# Altium schematic sheet STM32_Peripherals.SchDoc
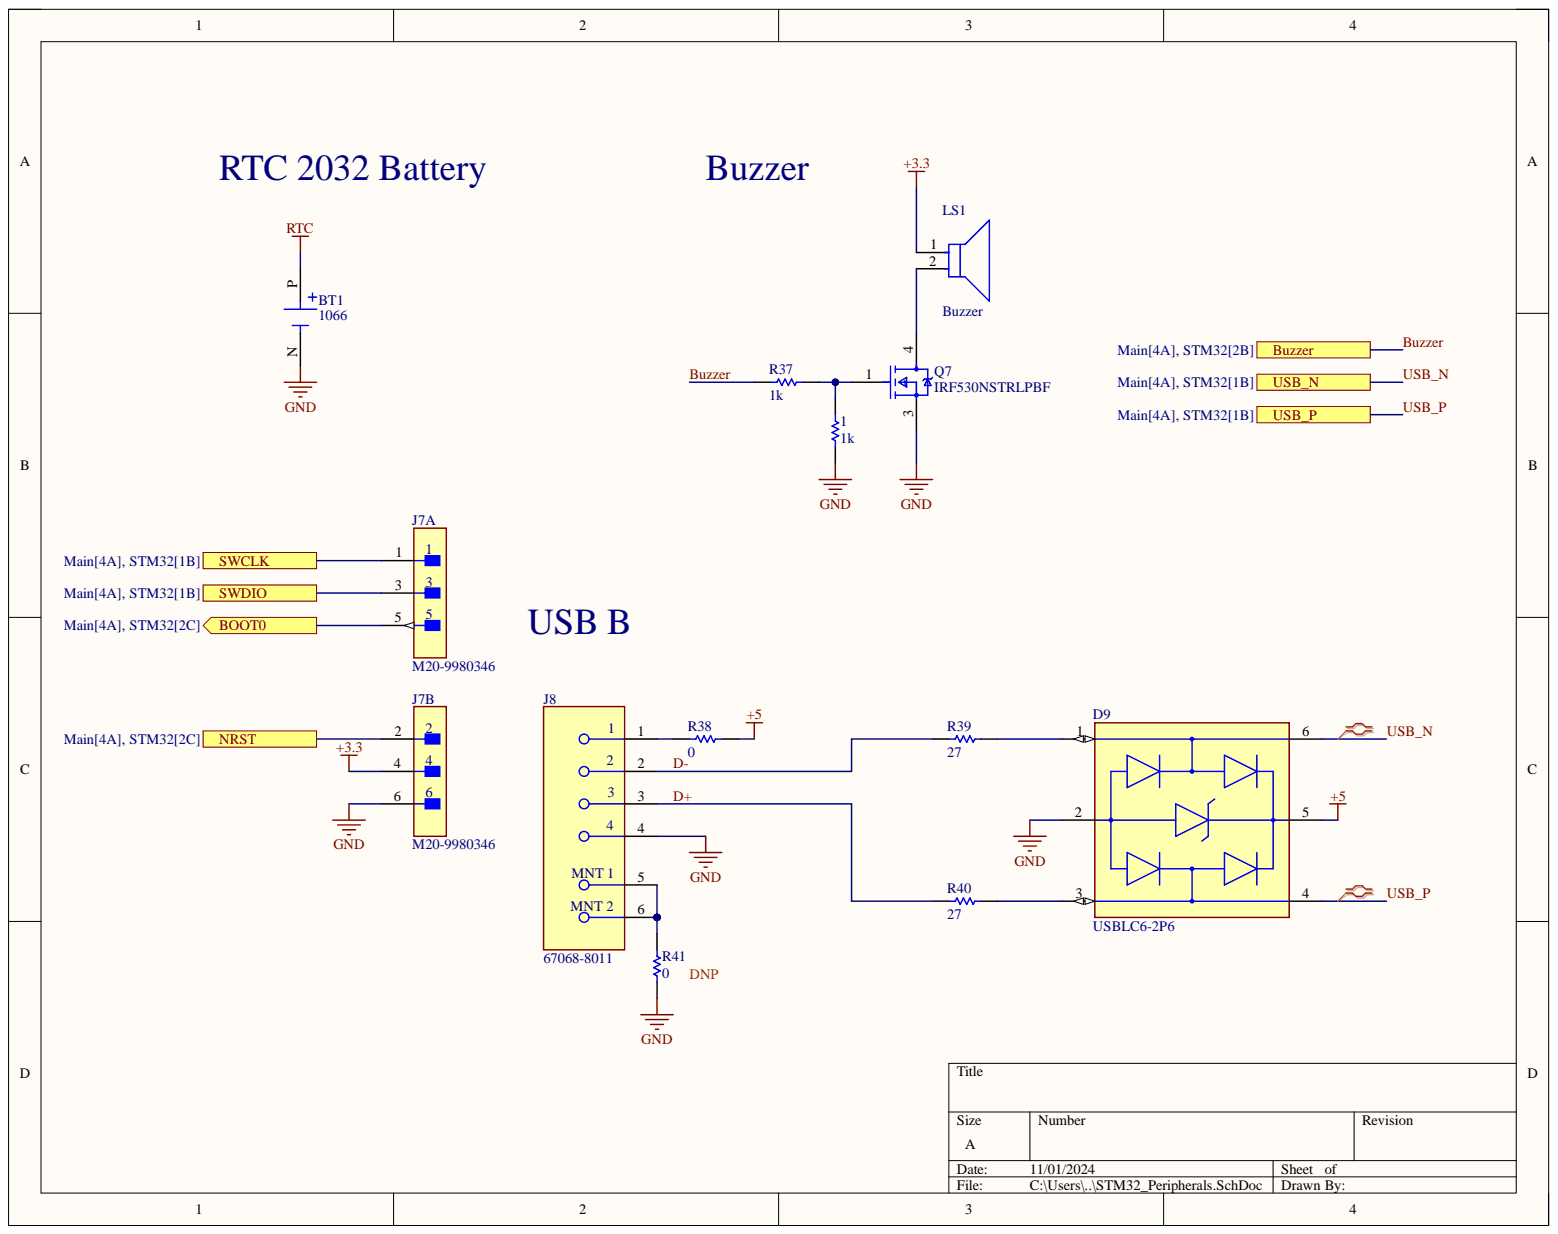
[diagram p0: STM32_Peripherals.SchDoc]

## Parts
1  1k  [RESC2013X50X30LL20T20]
BT1  1066  [BAT_1066]
D9  USBLC6-2P6  [FP-SOT-666-MFG]
J7  M20-9980346  [FP-M20-9980346-MFG]  (parts A,B)
J8  67068-8011  [FP-67068-8011-MFG]
LS1  Buzzer  [FP-PKB24SPCH3601-B0-MFG]
Q7  IRF530NSTRLPBF  [FP-PG-TO263-3-MFG]
R37  1k  [RESC2013X50X30LL20T20]
R38  0  [RESC2013X50X30LL20T20]
R39  27  [RESC2013X50X30LL20T20]
R40  27  [RESC2013X50X30LL20T20]
R41  0  [RESC2013X50X30LL20T20]

## Ports
BOOT0, Buzzer, NRST, SWCLK, SWDIO, USB_N, USB_P

## Nets
+3.3: J7.4 LS1.1
+5: D9.5 R38.2
GND: 1.1 BT1.N D9.2 J7.6 J8.4 Q7.3 R41.1
RTC: BT1.P
BOOT0: J7.5 ; port BOOT0
Buzzer: R37.1 ; port Buzzer
D+: J8.3 R40.1
D-: J8.2 R39.1
NRST: J7.2 ; port NRST
SWCLK: J7.1 ; port SWCLK
SWDIO: J7.3 ; port SWDIO
USB_N: D9.6 ; port USB_N
USB_P: D9.4 ; port USB_P
Net1_2: 1.2 Q7.1 R37.2
NetD9_1: D9.1 R39.2
NetD9_3: D9.3 R40.2
NetJ8_1: J8.1 R38.1
NetJ8_5: J8.5 J8.6 R41.2
NetLS1_2: LS1.2 Q7.4

## Library data of the parts
1 R37-R41 = CMP-2001-04896-1: Manufacturer: Vishay Dale; Part Number: CRCW08050000Z0EA; Component Kind: Standard; Library Name: Altium Content Vault
D9 = CMP-12225-000058-1: TVS DIODE 5.25V 17V SOT666; Breakdown Voltage: 6V; Capacitance: 3.5pF; Direction: Unidirectional; Element Configuration: Dual; ESD Protection: Yes; Leakage Current: 150nA; Number of Bidirectional Channels: 2; Number of Elements: 1; Operating Supply Voltage: 5V; Peak Pulse Current: 5A; Test Current: 1mA; Case/Package: SOT-666; Clamping Voltage: 17V; Component Kind: Standard; Contact Plating: Tin; Depth: 1.3mm; Height: 0.6mm; Lead Free: Lead Free; Length: 1.7mm; Library Name: Altium Content Vault; Max Breakdown Voltage: 6V; Max Operating Temperature: 125°C; Max Reverse Leakage Current: 150nA; Min Breakdown Voltage: 6V; Min Operating Temperature: -40°C; Mount: Surface Mount; Number of Channels: 2; Number of Pins: 6; Package Quantity: 1; Packaging: Tape and Reel; Polarity: Unidirectional; Power Line Protection: Yes; Radiation Hardening: No; REACH SVHC: No SVHC; Reverse Breakdown Voltage: 6V; Reverse Standoff Voltage: 5V; Termination: SMD/SMT; Width: 1.3mm; Working Voltage: 5V
J7 = CMP-2000-06636-2: CONN HEADER VERT 6POS 2.54MM; Manufacturer: Harwin; Conn Gender: Male; Conn Orientation: Vertical; Current Rating: 3A; Number Of Contacts: 6; Automotive: No; Category: Conn; Component Kind: Standard; ComponentLink2Description: Datasheet; ComponentLink2URL: https://cdn.harwin.com/pdfs/M20-998.pdf; Contact Material: Tin,Nickel; Device Class L1: Connectors; Device Class L2: Headers and Wire Housings; Device Class L3: unset; Digikey Description: CONN HEADER VERT 6POS 2.54MM; Height: 8.99mm; Lead Free: Yes; Library Name: Altium Content Vault; Manufacturer Part Number: M20-9980346; Mouser Description: 2.54mm (0.1") Pitch DIL Vertical PC Tail Pin Header, 6.1mm mating pin height, tin, 3+3 contacts; Number Of Rows: 2; Octopart: https://octopart.com/m20-9980346-harwin-5436545; Package: M20-9980346; Pitch: 2.54mm; RoHS: TRUE; Temperature: -40°C to +105°C; Temperature Range High: +105°C; Temperature Range Low: -40°C
J8 = CMP-00257-00942133-1: 67068-8011 Conn USB 2.0 Type B RCP 4 POS 2.5mm Solder RA Thru-Hole 4 Terminal 1 Port USB 2.0 Tray; NexarPartId: 942133; Connector Type: Receptacle, USB; Current Rating: 1.5A; Gender: Receptacle; Max Voltage Rating (AC): 30V; Number of Circuits: 4; Number of Ports: 1; USB Standard: USB 2.0; Color: White; Component Kind: Standard; Contact Material: Bronze, Gold, Nickel; Contact Plating: Gold; Depth: 17mm; Height: 11.25mm; Housing Material: Nylon; Lead Pitch: 2.5mm; Length: 12mm; Library Name: Altium Content Vault; Max Operating Temperature: 50°C; Min Operating Temperature: 0°C; Mount: Through Hole; Number of Contacts: 4; Number of Positions: 4; Number of Rows: 2; Number of Terminals: 4; Orientation: Right Angle; Pitch: 2.5mm; Radiation Hardening: No; REACH SVHC: Unknown; RoHS: Compliant; Schedule B: 8536695050; Termination: Solder; Voltage Rating: 30V
LS1 = CMP-06203-000001-2: AUDIO PIEZO TRANSDUCER 3-15V TH; Frequency: 3.6kHz; Max Frequency: 4.1kHz; Max Supply Current: 16mA; Max Supply Voltage: 15V; Min Supply Voltage: 3V; Nominal Supply Voltage (DC): 12V; Washable: No; Component Kind: Standard; Diameter: 24.3mm; Height: 9.7mm; Lead Free: Lead Free; Length: 24.3mm; Library Name: Altium Content Vault; Max Operating Temperature: 70°C; Max Supply Voltage (DC): 15V; Min Operating Temperature: -20°C; Min Supply Voltage (DC): 3V; Mount: Flush; Nominal Supply Current: 16mA; Operating Supply Current: 16mA; Package Quantity: 650; Packaging: Bulk; Radiation Hardening: No; REACH SVHC: No SVHC; Self Resonant Frequency: 3.6kHz; Termination: PC Pins; Voltage Rating: 12V; Voltage Rating (DC): 15V
Q7 = CMP-32901-000039-1: MOSFET N-CH 100V 17A D2PAK; Manufacturer: Infineon; Depletion Mode: False; Drain To Source Resistance: 90mΩ; Gate Charge At Vgs: 37nC @ 10V; Gate To Source Voltage: 20V; Input Capacitace At Vds: 920pF @ 25V; Max Forward Diode Voltage: 1.3V; Max Junction Temp: +175°C; Number Of Channels: 1; Power Dissipation: 3.8W; Pulse Drain Current: 60A; Reverse Recovery Charge: 320nC; Reverse Recovery Time: 93ns; Rthja Max: 40°C/W; Standoff Height: 0mm; Threshold Vgs Max: 4V; Threshold Vgs Min: 2V; Turn Off Delay Time: 35ns; Turn On Delay Time: 9.2ns; Automotive: No; Category: Trans; Component Kind: Standard; ComponentLink2Description: Datasheet; ComponentLink2URL: https://www.infineon.com/dgdl/irf530nspbf.pdf?fileId=5546d462533600a4015355e38eb4199c; Continuous Drain Current: 17A; Device Class L1: Discrete Semiconductors; Device Class L2: Transistors; Device Class L3: MOSFETs; Digikey Description: MOSFET N-CH 100V 17A D2PAK; Drain To Source Breakdown Voltage: 100V; Drain To Source Voltage: 100V; Footprint Url: https://www.infineon.com/cms/en/product/packages/PG-TO263/PG-TO263-3-901/; Height: 5.084mm; Lead Free: Yes; Library Name: Altium Content Vault; Manufacturer Part Number: IRF530NSTRLPBF; Number Of N Channels: 1; Octopart: https://octopart.com/irf530nstrlpbf-infineon-65873402; Package: PG-TO263-3; RoHS: TRUE; Temperature: -55°C to +175°C; Temperature Range High: +175°C; Temperature Range Low: -55°C
BT1 = 1066: Availability: Unavailable; Component Kind: Standard; Library Name: 1066.SchLib; MP: 1066; Package: None; Price: None
